# Altium schematic sheet Power_Source.SchDoc
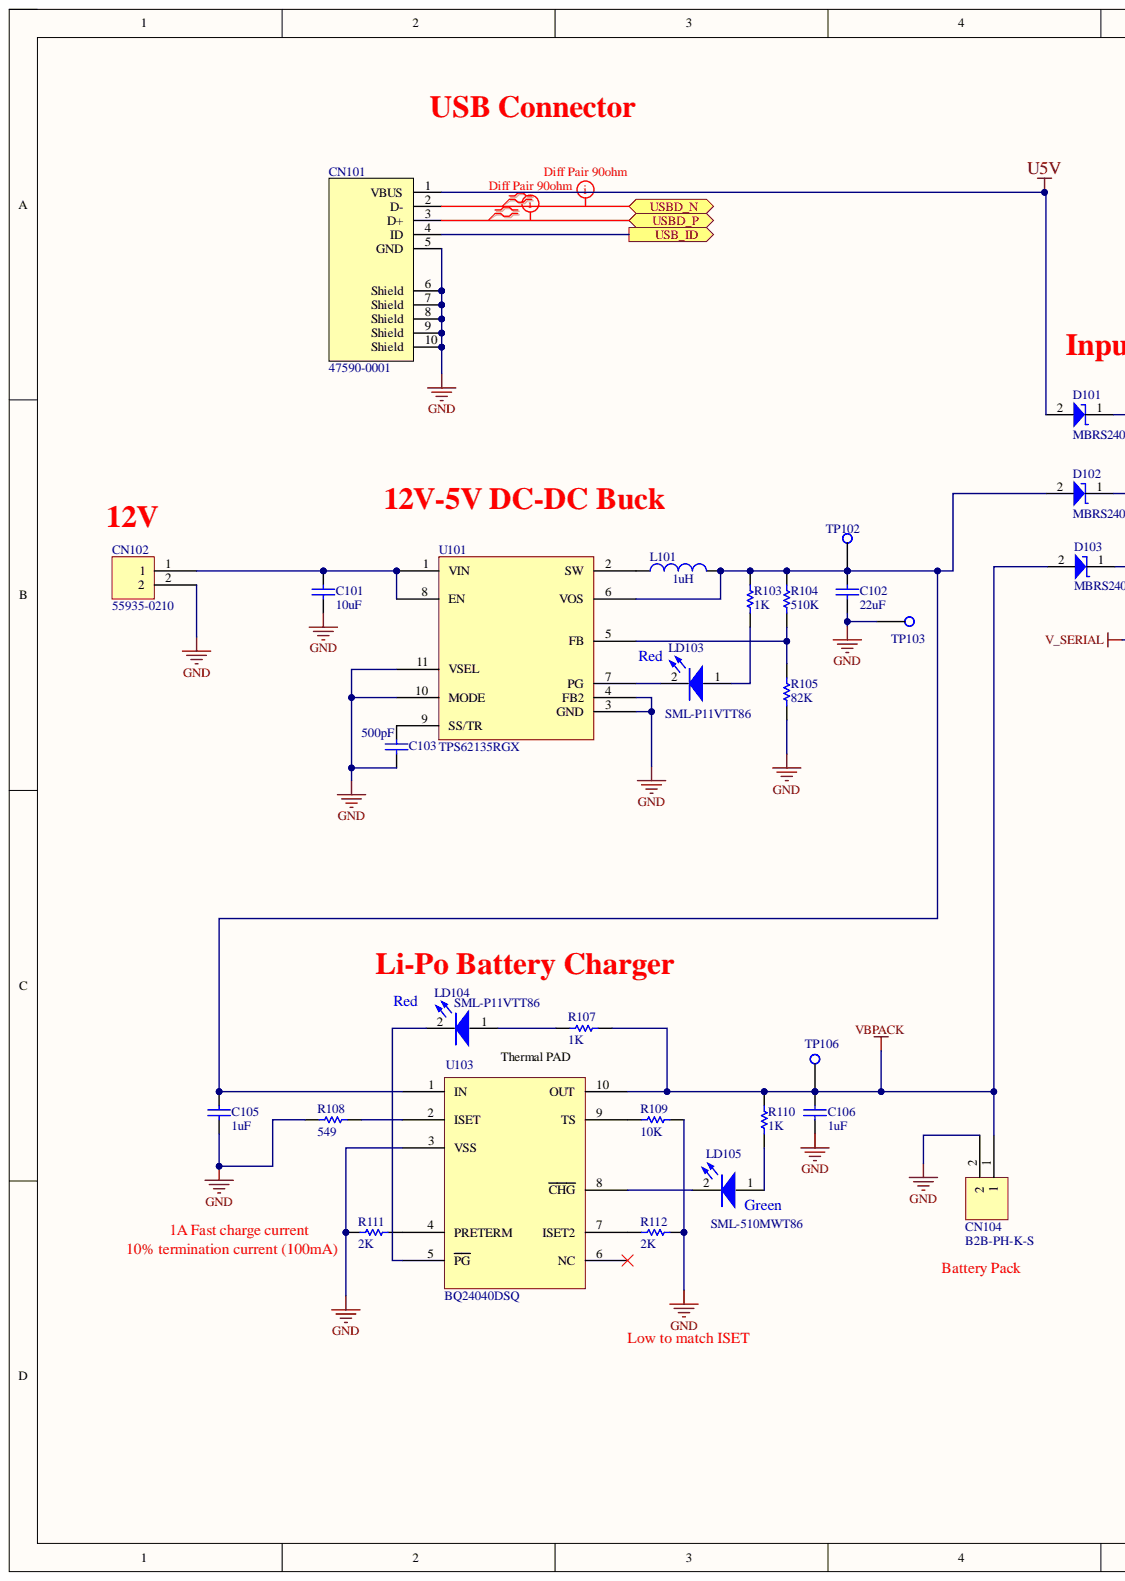
[diagram p0: Power_Source.SchDoc, left]
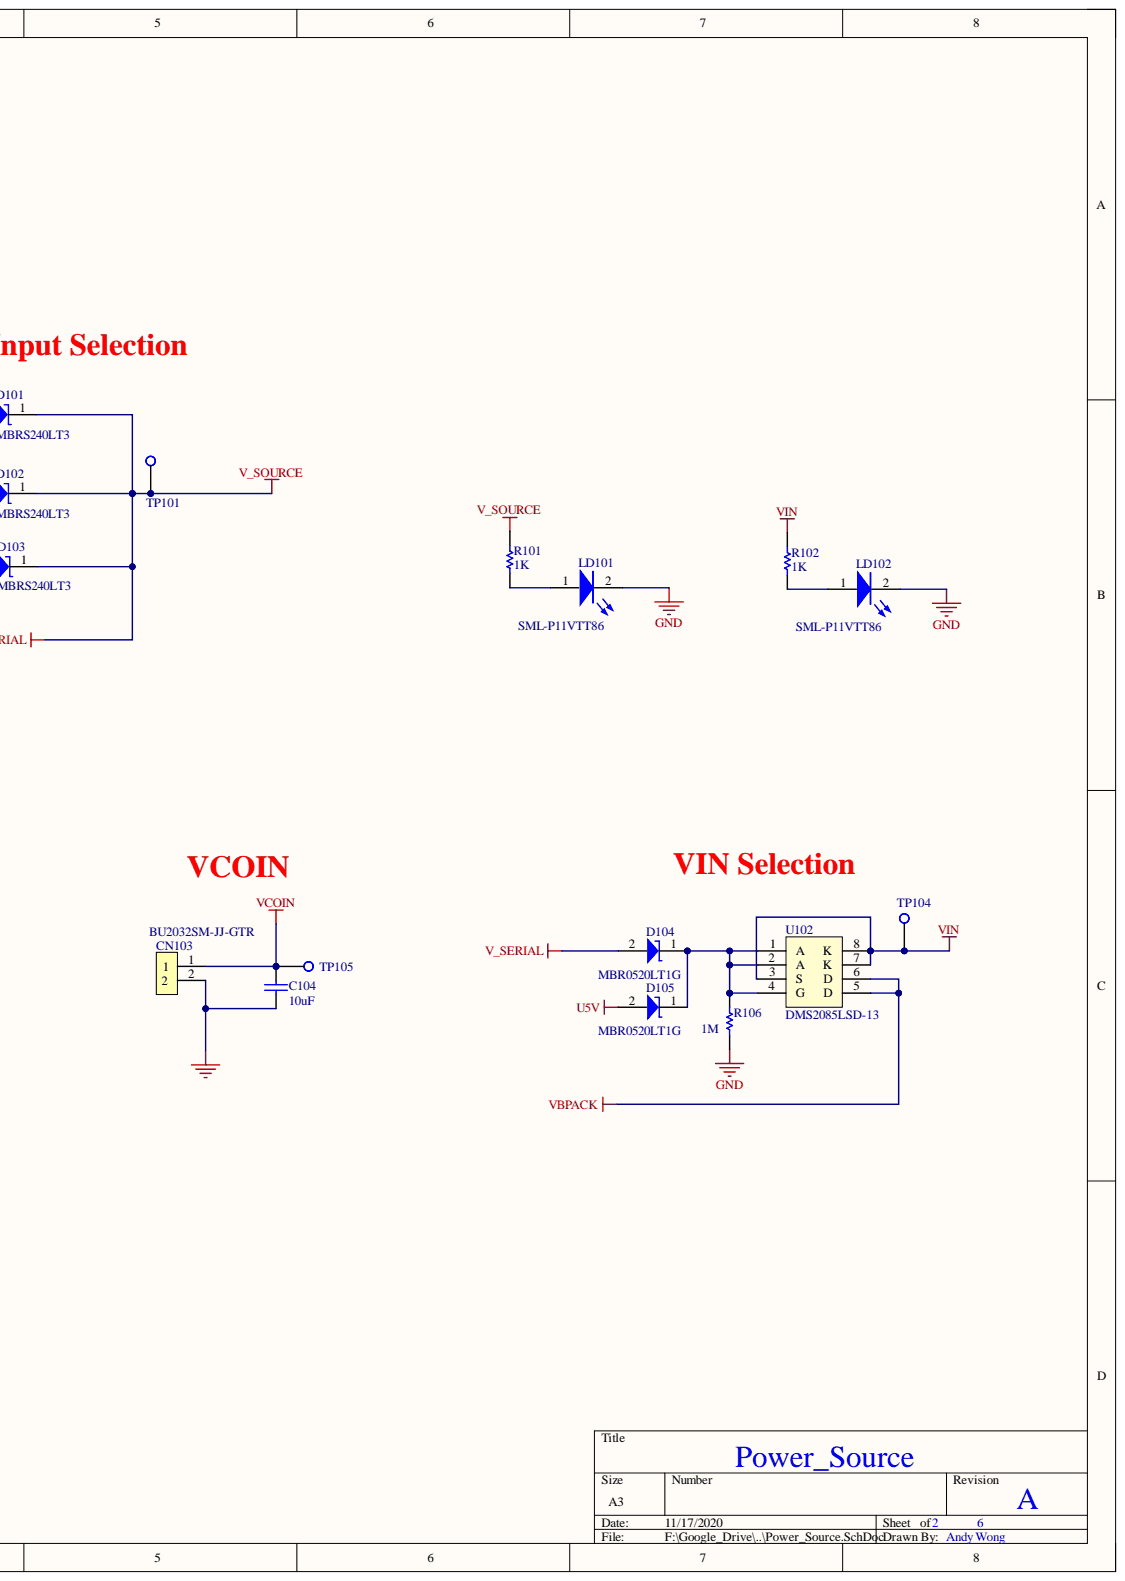
[diagram p1: Power_Source.SchDoc, right]

## Parts
C101  10uF  [Cap 0805]
C102  22uF  [Cap 0805]
C103  500pF  [Cap 0402]
C104  10uF  [CAP 0603]
C105  1uF  [Cap 0402]
C106  1uF  [Cap 0402]
CN101  47590-0001  [MOLEX_47590001]
CN102  55935-0210  [559350210]
CN103  BU2032SM-JJ-GTR  [BU2032SM-JJ-GTR]
CN104  B2B-PH-K-S  [B2B-PH-K-S]
D101  MBRS240LT3  [MBRS240LT3]
D102  MBRS240LT3  [MBRS240LT3]
D103  MBRS240LT3  [MBRS240LT3]
D104  MBR0520LT1G  [MBR0520LT1G]
D105  MBR0520LT1G  [MBR0520LT1G]
L101  1uH  [IHLP-2525CZ-01]
LD101  SML-P11VTT86  [LED 0402]
LD102  SML-P11VTT86  [LED 0402]
LD103  SML-P11VTT86  [LED 0402]
LD104  SML-P11VTT86  [LED 0402]
LD105  SML-510MWT86  [LED 0603]
R101  1K  [Res 0402]
R102  1K  [Res 0402]
R103  1K  [Res 0402]
R104  510K  [Res 0402]
R105  82K  [Res 0402]
R106  1M  [Res 0402]
R107  1K  [Res 0402]
R108  549  [Res 0402]
R109  10K  [Res 0402]
R110  1K  [Res 0402]
R111  2K  [Res 0402]
R112  2K  [Res 0402]
TP101  Test Point  [TP]  (value not drawn)
TP102  Test Point  [TP]  (value not drawn)
TP103  Test Point  [TP]  (value not drawn)
TP104  Test Point  [TP]  (value not drawn)
TP105  Test Point  [TP]  (value not drawn)
TP106  Test Point  [TP]  (value not drawn)
U101  TPS62135RGX  [TPS62135RGX]
U102  DMS2085LSD-13  [DMS2085LSD-13]
U103  BQ24040DSQ  [BQ24040DSQ]

## Ports
USB_ID, USBD_N, USBD_P

## Nets
GND: C101.1 C102.1 C103.1 C104.1 C105.1 C106.1 CN101.5(GND) CN101.6(Shield) CN101.7(Shield) CN101.8(Shield) CN101.9(Shield) CN101.10(Shield) CN102.2 CN103.2 CN104.2 LD101.2 LD102.2 R105.1 R106.2 R108.2 R109.2 R111.2 R112.1 TP103.1 U101.3(GND) U101.4(FB2) U101.10(MODE) U101.11(VSEL) U103.3(VSS)
U5V: CN101.1(VBUS) D101.2 D105.2
V_SERIAL: D101.1 D102.1 D103.1 D104.2 R101.2 TP101.1
VBPACK: C106.2 CN104.1 D103.2 R107.1 R110.1 TP106.1 U102.5(D) U102.6(D) U103.10(OUT)
VCOIN: C104.2 CN103.1 TP105.1
VIN: R102.2 TP104.1 U102.3(S) U102.7(K) U102.8(K)
USB_ID: CN101.4(ID) ; port USB_ID
USBD_N: CN101.2(D-) ; port USBD_N
USBD_P: CN101.3(D+) ; port USBD_P
NetC101_2: C101.2 CN102.1 U101.1(VIN) U101.8(EN)
NetC102_2: C102.2 C105.2 D102.2 L101.2 R103.1 R104.2 TP102.1 U101.6(VOS) U103.1(IN)
NetC103_2: C103.2 U101.9(SS/TR)
NetD104_1: D104.1 D105.1 R106.1 U102.1(A) U102.2(A) U102.4(G)
NetL101_1: L101.1 U101.2(SW)
NetLD101_1: LD101.1 R101.1
NetLD102_1: LD102.1 R102.1
NetLD103_1: LD103.1 R103.2
NetLD103_2: LD103.2 U101.7(PG)
NetLD104_1: LD104.1 R107.2
NetLD104_2: LD104.2 U103.5(PG)
NetLD105_1: LD105.1 R110.2
NetLD105_2: LD105.2 U103.8(CHG)
NetR104_1: R104.1 R105.2 U101.5(FB)
NetR108_1: R108.1 U103.2(ISET)
NetR109_1: R109.1 U103.9(TS)
NetR111_1: R111.1 U103.4(PRETERM)
NetR112_2: R112.2 U103.7(ISET2)

Not connected: U103 6(NC)

## Library data of the parts
C101 = Cap: GRM21BR61C106KE15K, 16V X5R 0805; Component Kind: Standard; LatestRevisionDate: 17-Jul-2002; LatestRevisionNote: Re-released for DXP Platform.; Library Name: Miscellaneous Devices.IntLib; PackageDescription: Radial Cap, Thru-Hole; 2 Leads; 0.3 in Pin Spacing; PackageReference: RAD-0.3; Published: 8-Jun-2000; Signal Integrity: Cap; Simulation: CAP; Publisher: Altium Limited
C102 = Cap: GRM21BR60J226ME39K , 0805; Component Kind: Standard; LatestRevisionDate: 17-Jul-2002; LatestRevisionNote: Re-released for DXP Platform.; Library Name: Miscellaneous Devices.IntLib; PackageDescription: Radial Cap, Thru-Hole; 2 Leads; 0.3 in Pin Spacing; PackageReference: RAD-0.3; Published: 8-Jun-2000; Signal Integrity: Cap; Simulation: CAP; Publisher: Altium Limited
C103 = Cap: AVX 04025C501KAT2A, 0402; Component Kind: Standard; LatestRevisionDate: 17-Jul-2002; LatestRevisionNote: Re-released for DXP Platform.; Library Name: Miscellaneous Devices.IntLib; PackageDescription: Radial Cap, Thru-Hole; 2 Leads; 0.3 in Pin Spacing; PackageReference: RAD-0.3; Published: 8-Jun-2000; Signal Integrity: Cap; Simulation: CAP; Publisher: Altium Limited
C104 = Cap: GRM188R60J106ME47D, 0603; Component Kind: Standard; LatestRevisionDate: 17-Jul-2002; LatestRevisionNote: Re-released for DXP Platform.; Library Name: Miscellaneous Devices.IntLib; PackageDescription: Radial Cap, Thru-Hole; 2 Leads; 0.3 in Pin Spacing; PackageReference: RAD-0.3; Published: 8-Jun-2000; Signal Integrity: Cap; Simulation: CAP; Publisher: Altium Limited
C105 C106 = Cap: GRM155R61A105KE15, 0402; Component Kind: Standard; LatestRevisionDate: 17-Jul-2002; LatestRevisionNote: Re-released for DXP Platform.; Library Name: Miscellaneous Devices.IntLib; PackageDescription: Radial Cap, Thru-Hole; 2 Leads; 0.3 in Pin Spacing; PackageReference: RAD-0.3; Published: 8-Jun-2000; Signal Integrity: Cap; Simulation: CAP; Publisher: Altium Limited
CN101 = 47590-0001: RightAngle SMT TypeABVersion 2.0 Micro USB Conn Skt; 30Vac; 1.8A 47650 MICRO-USB; Component Kind: Standard; Library Name: MA_Eva_Board.SchLib
CN102 = 55935-0210: 12V DC Connector; Component Kind: Standard; Library Name: MA_Eva_Board.SchLib
CN103 = BU2032SM-JJ-GTR: Coin battery clip; Component Kind: Standard; Library Name: MA_Eva_Board.SchLib
CN104 = B2B-PH-K-S: Lipo Connector, Top Entry, 2 mm, 2 Contacts, Header, PH Series, Through Hole, 1 Rows; Component Kind: Standard; Library Name: MA_Eva_Board.SchLib
L101 = Inductor: IHLP2525CZER1R0M01; Code_IEC: 1005; Code_JEITA: 0402; Component Kind: Standard; LatestRevisionDate: 29-May-2009; LatestRevisionNote: IPC-7351 Footprint Added.; Library Name: Miscellaneous Devices.IntLib; PackageDescription: Chip Inductor; PackageReference: 0402-A; Published: 8-Jun-2000; Signal Integrity: Inductor; Simulation: INDUCTOR; Publisher: Altium Limited
LD101-LD104 = LED_Green: LED RED 0402 SMD; Component Kind: Standard; Library Name: MA_Eva_Board.SchLib
LD105 = LED_Green: LED GREEN DIFFUSED 0603 SMD; Component Kind: Standard; Library Name: MA_Eva_Board.SchLib
R101-R103 R107 R110 = Res1: ERA-2AEB102X, 0402; Component Kind: Standard; LatestRevisionDate: 17-Jul-2002; LatestRevisionNote: Re-released for DXP Platform.; Library Name: Miscellaneous Devices.IntLib; PackageDescription: Axial Device, Thru-Hole; 2 Leads; 0.3 in Pin Spacing; PackageReference: AXIAL-0.3; Published: 8-Jun-2000; Signal Integrity: Res; Simulation: RESISTOR; Publisher: Altium Limited
R104 = Res1: ERJ-2RKF5103X, 0402; Component Kind: Standard; LatestRevisionDate: 17-Jul-2002; LatestRevisionNote: Re-released for DXP Platform.; Library Name: Miscellaneous Devices.IntLib; PackageDescription: Axial Device, Thru-Hole; 2 Leads; 0.3 in Pin Spacing; PackageReference: AXIAL-0.3; Published: 8-Jun-2000; Signal Integrity: Res; Simulation: RESISTOR; Publisher: Altium Limited
R105 = Res1: ERA-2AED823X, 0402; Component Kind: Standard; LatestRevisionDate: 17-Jul-2002; LatestRevisionNote: Re-released for DXP Platform.; Library Name: Miscellaneous Devices.IntLib; PackageDescription: Axial Device, Thru-Hole; 2 Leads; 0.3 in Pin Spacing; PackageReference: AXIAL-0.3; Published: 8-Jun-2000; Signal Integrity: Res; Simulation: RESISTOR; Publisher: Altium Limited
R106 = Res1: ERJ-2RKF1004X, 0402; Component Kind: Standard; LatestRevisionDate: 17-Jul-2002; LatestRevisionNote: Re-released for DXP Platform.; Library Name: Miscellaneous Devices.IntLib; PackageDescription: Axial Device, Thru-Hole; 2 Leads; 0.3 in Pin Spacing; PackageReference: AXIAL-0.3; Published: 8-Jun-2000; Signal Integrity: Res; Simulation: RESISTOR; Publisher: Altium Limited
R108 = Res1: ERJ-2RKF5490X, 0402; Component Kind: Standard; LatestRevisionDate: 17-Jul-2002; LatestRevisionNote: Re-released for DXP Platform.; Library Name: Miscellaneous Devices.IntLib; PackageDescription: Axial Device, Thru-Hole; 2 Leads; 0.3 in Pin Spacing; PackageReference: AXIAL-0.3; Published: 8-Jun-2000; Signal Integrity: Res; Simulation: RESISTOR; Publisher: Altium Limited
R109 = Res1: ERA-2AED103X, 0402; Component Kind: Standard; LatestRevisionDate: 17-Jul-2002; LatestRevisionNote: Re-released for DXP Platform.; Library Name: Miscellaneous Devices.IntLib; PackageDescription: Axial Device, Thru-Hole; 2 Leads; 0.3 in Pin Spacing; PackageReference: AXIAL-0.3; Published: 8-Jun-2000; Signal Integrity: Res; Simulation: RESISTOR; Publisher: Altium Limited
R111 R112 = Res1: ERA-2AEB202X, 0402; Component Kind: Standard; LatestRevisionDate: 17-Jul-2002; LatestRevisionNote: Re-released for DXP Platform.; Library Name: Miscellaneous Devices.IntLib; PackageDescription: Axial Device, Thru-Hole; 2 Leads; 0.3 in Pin Spacing; PackageReference: AXIAL-0.3; Published: 8-Jun-2000; Signal Integrity: Res; Simulation: RESISTOR; Publisher: Altium Limited
U101 = TPS62135RGX: 3-V to 17-V 4-A Step-Down Converters; Component Kind: Standard; Library Name: MA_Eva_Board.SchLib
U102 = DMS2085LSD-13: P-CHANNEL ENHANCEMENT MODE MOSFET  WITH INTEGRATED SCHOTTKY DIODE; Component Kind: Standard; Library Name: MA_Eva_Board.SchLib
U103 = BQ24040DSQ: 1A, Single-Input, Single Cell Li-Ion and Li-Pol Battery Charger; Component Kind: Standard; Library Name: MA_Eva_Board.SchLib
D101-D103 = MBRS240LT3: Component Kind: Standard; Description: MBRS240LT3; Library Name: MA_Eva_Board.SchLib
D104 D105 = MBR0520LT1G: Component Kind: Standard; Description: MBR0520LT1G; Library Name: MA_Eva_Board.SchLib
TP101-TP106 = Test Point: Component Kind: Standard; Description: Test Point; Library Name: MA_Eva_Board.SchLib
